# Altium schematic sheet sch.SchDoc(sch)
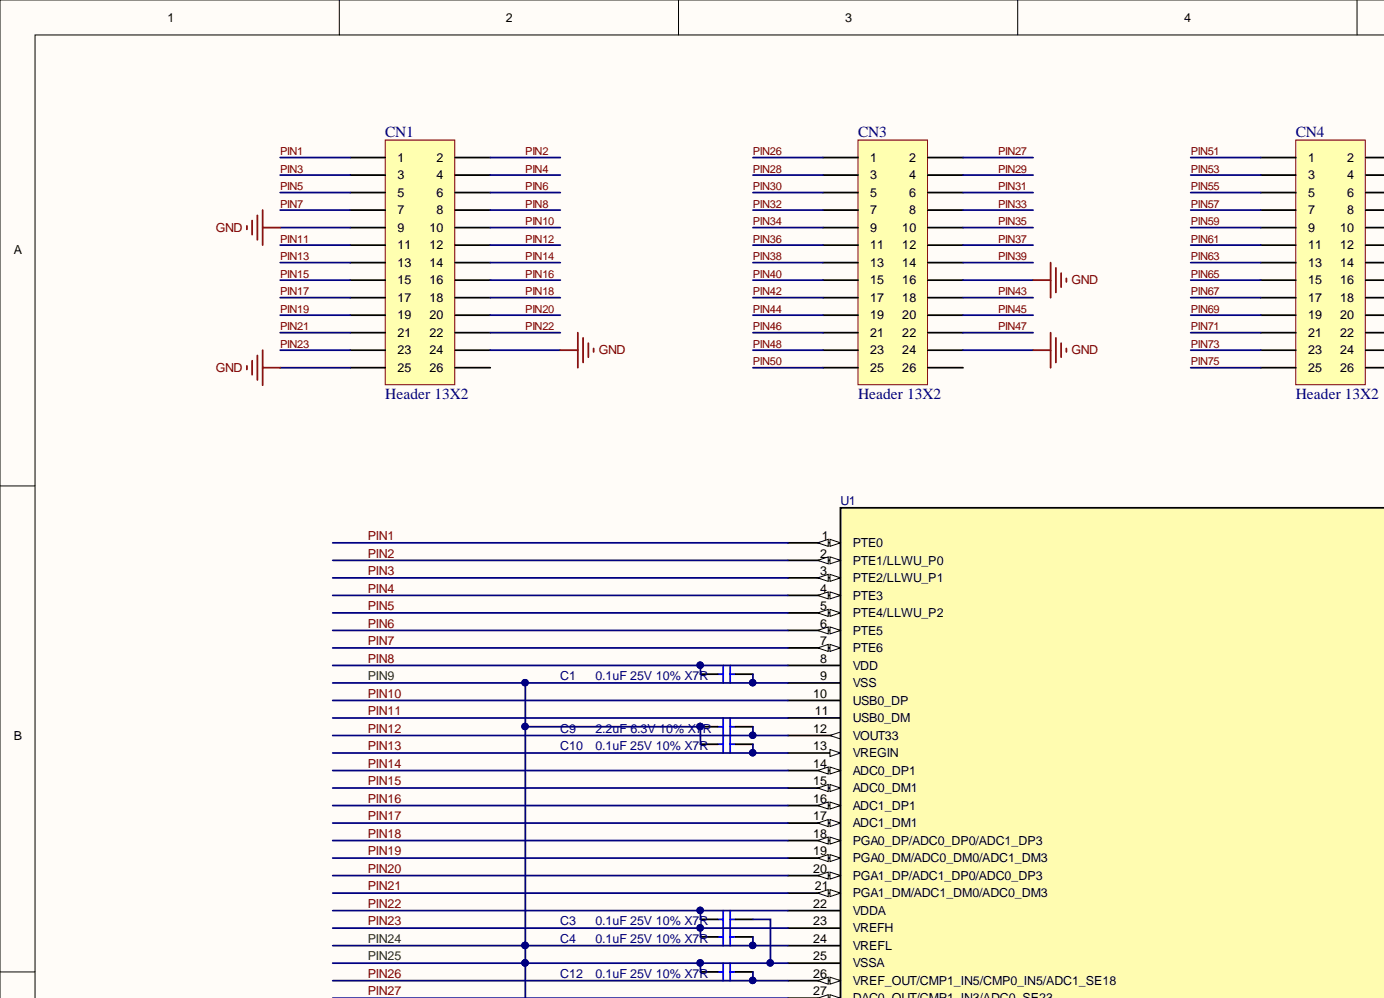
[diagram p0: sch.SchDoc, top left]
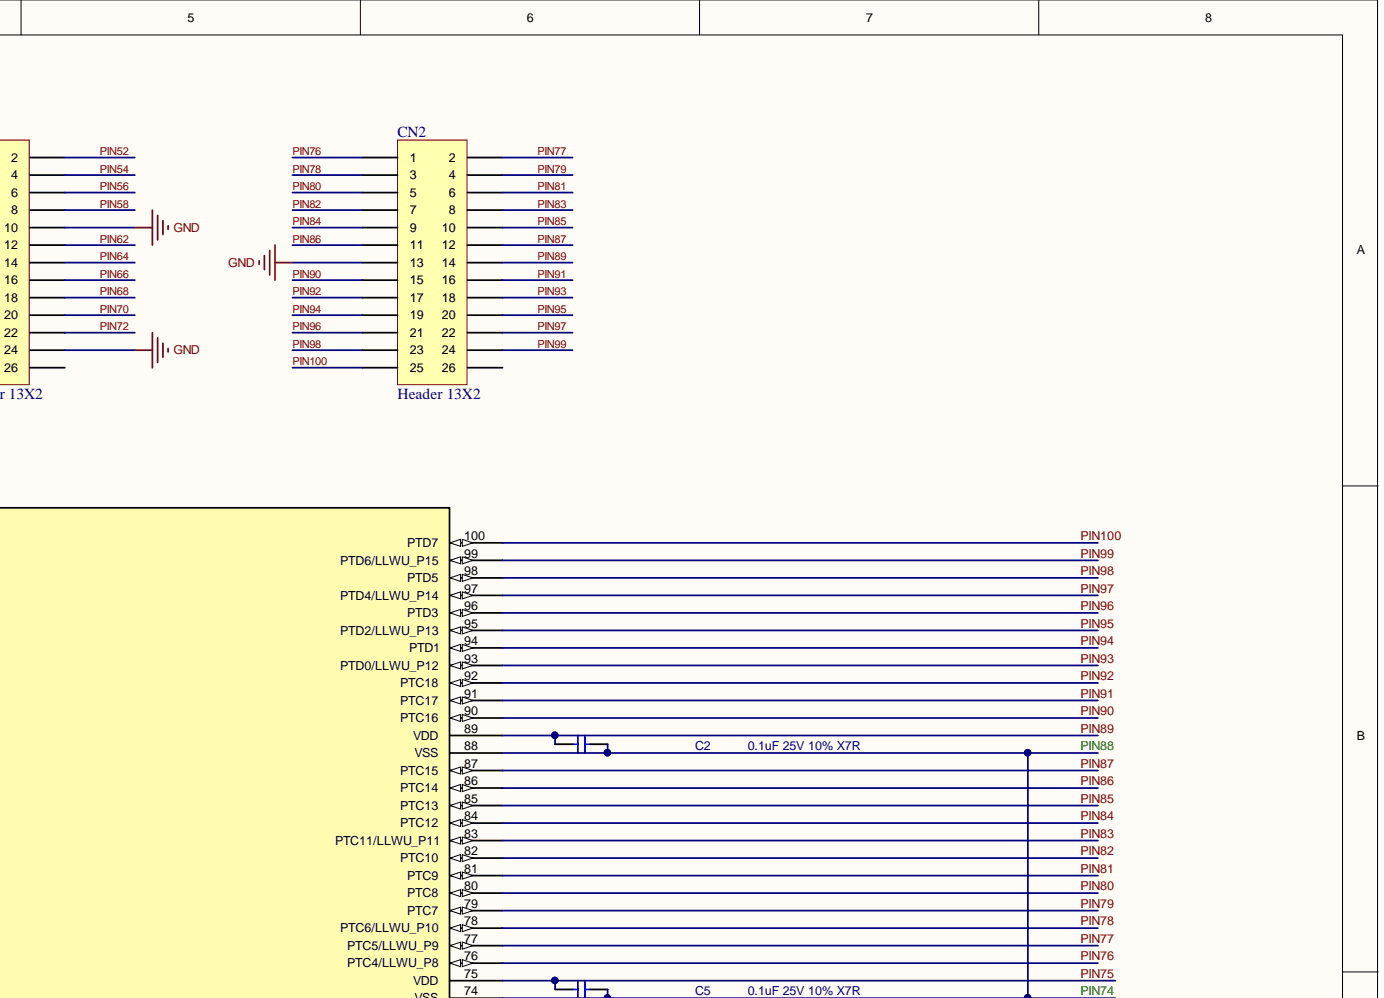
[diagram p1: sch.SchDoc, top right]
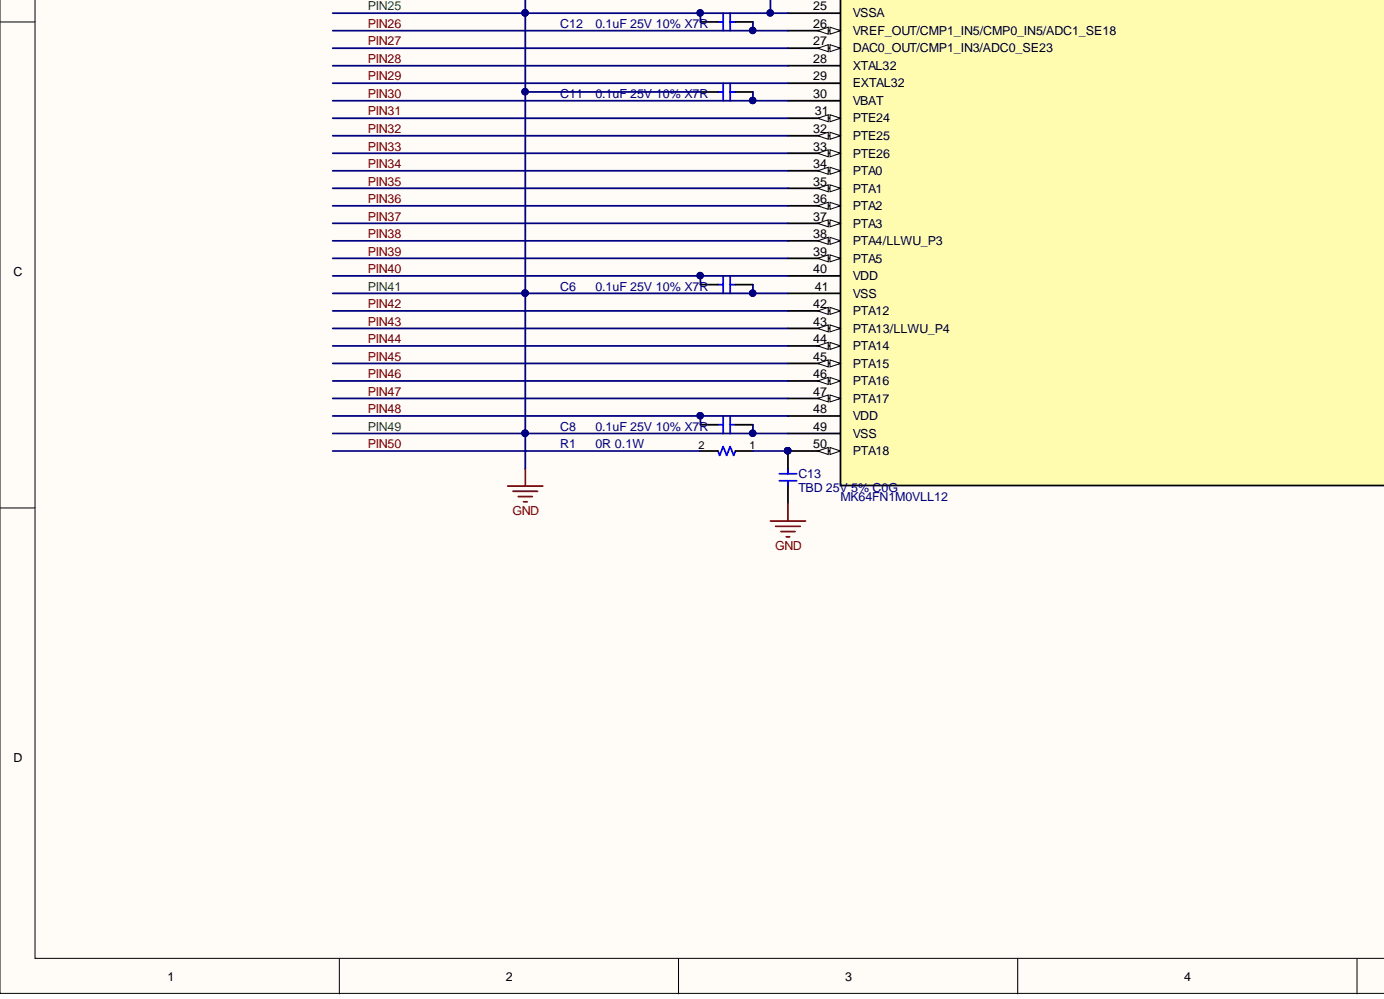
[diagram p2: sch.SchDoc, bottom left]
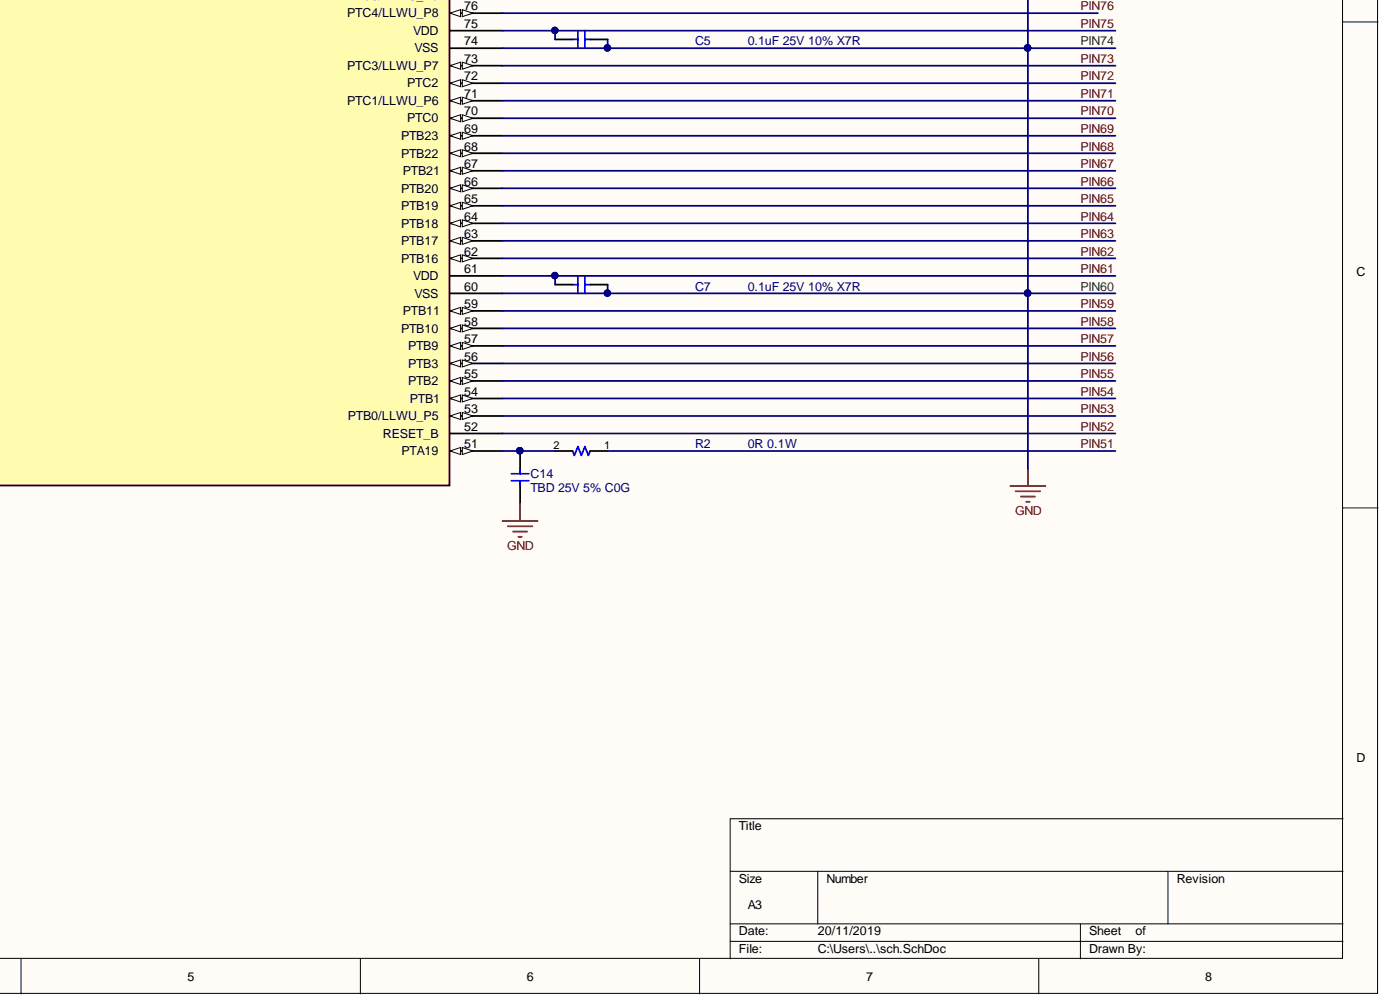
[diagram p3: sch.SchDoc, bottom right]

## Parts
C1  0.1uF 25V 10% X7R  value 0.1uF  MATERIAL: X7R  TOLERANCE: 10%  [CAPC1608X90N]
C2  0.1uF 25V 10% X7R  value 0.1uF  [CAPC1608X90N]
C3  0.1uF 25V 10% X7R  value 0.1uF  MATERIAL: X7R  TOLERANCE: 10%  [CAPC1608X90N]
C4  0.1uF 25V 10% X7R  value 0.1uF  MATERIAL: X7R  TOLERANCE: 10%  [CAPC1608X90N]
C5  0.1uF 25V 10% X7R  value 0.1uF  [CAPC1608X90N]
C6  0.1uF 25V 10% X7R  value 0.1uF  MATERIAL: X7R  TOLERANCE: 10%  [CAPC1608X90N]
C7  0.1uF 25V 10% X7R  value 0.1uF  [CAPC1608X90N]
C8  0.1uF 25V 10% X7R  value 0.1uF  MATERIAL: X7R  TOLERANCE: 10%  [CAPC1608X90N]
C9  2.2uF 6.3V 10% X7R  value 2.2uF  MATERIAL: X7R  TOLERANCE: 10%  [CAPC1608X90N]
C10  0.1uF 25V 10% X7R  value 0.1uF  MATERIAL: X7R  TOLERANCE: 10%  [CAPC1608X90N]
C11  0.1uF 25V 10% X7R  value 0.1uF  MATERIAL: X7R  TOLERANCE: 10%  [CAPC1608X90N]
C12  0.1uF 25V 10% X7R  value 0.1uF  MATERIAL: X7R  TOLERANCE: 10%  [CAPC1608X90N]
C13  TBD 25V 5% C0G  value TBD  [CAPC1608X90N]
C14  TBD 25V 5% C0G  value TBD  VOLTAGE: 25V  [CAPC1608X90N]
CN1  Header 13X2  [HDR2X13]
CN2  Header 13X2  [HDR2X13]
CN3  Header 13X2  [HDR2X13]
CN4  Header 13X2  [HDR2X13]
R1  0R 0.1W  value 0R  [RESC1608X40N]
R2  0R 0.1W  value 0R  [RESC1608X40N]
U1  MK64FN1M0VLL12  [QFP50P1600X1600X160-100N]

## Nets
GND: C1.1 C2.1 C3.1 C4.1 C5.1 C6.1 C7.1 C8.1 C9.2 C10.2 C11.2 C12.2 C13.2 C14.2 CN1.9 CN1.24 CN1.25 CN2.13 CN3.16 CN3.24 CN4.10 CN4.24 U1.9(VSS) U1.24(VREFL) U1.25(VSSA) U1.41(VSS) U1.49(VSS) U1.60(VSS) U1.74(VSS) U1.88(VSS)
PIN1: CN1.1 U1.1(PTE0)
PIN2: CN1.2 U1.2(PTE1/LLWU_P0)
PIN3: CN1.3 U1.3(PTE2/LLWU_P1)
PIN4: CN1.4 U1.4(PTE3)
PIN5: CN1.5 U1.5(PTE4/LLWU_P2)
PIN6: CN1.6 U1.6(PTE5)
PIN7: CN1.7 U1.7(PTE6)
PIN8: C1.2 CN1.8 U1.8(VDD)
PIN10: CN1.10 U1.10(USB0_DP)
PIN11: CN1.11 U1.11(USB0_DM)
PIN12: C9.1 CN1.12 U1.12(VOUT33)
PIN13: C10.1 CN1.13 U1.13(VREGIN)
PIN14: CN1.14 U1.14(ADC0_DP1)
PIN15: CN1.15 U1.15(ADC0_DM1)
PIN16: CN1.16 U1.16(ADC1_DP1)
PIN17: CN1.17 U1.17(ADC1_DM1)
PIN18: CN1.18 U1.18(PGA0_DP/ADC0_DP0/ADC1_DP3)
PIN19: CN1.19 U1.19(PGA0_DM/ADC0_DM0/ADC1_DM3)
PIN20: CN1.20 U1.20(PGA1_DP/ADC1_DP0/ADC0_DP3)
PIN21: CN1.21 U1.21(PGA1_DM/ADC1_DM0/ADC0_DM3)
PIN22: C3.2 CN1.22 U1.22(VDDA)
PIN23: C4.2 CN1.23 U1.23(VREFH)
PIN26: C12.1 CN3.1 U1.26(VREF_OUT/CMP1_IN5/CMP0_IN5/ADC1_SE18)
PIN27: CN3.2 U1.27(DAC0_OUT/CMP1_IN3/ADC0_SE23)
PIN28: CN3.3 U1.28(XTAL32)
PIN29: CN3.4 U1.29(EXTAL32)
PIN30: C11.1 CN3.5 U1.30(VBAT)
PIN31: CN3.6 U1.31(PTE24)
PIN32: CN3.7 U1.32(PTE25)
PIN33: CN3.8 U1.33(PTE26)
PIN34: CN3.9 U1.34(PTA0)
PIN35: CN3.10 U1.35(PTA1)
PIN36: CN3.11 U1.36(PTA2)
PIN37: CN3.12 U1.37(PTA3)
PIN38: CN3.13 U1.38(PTA4/LLWU_P3)
PIN39: CN3.14 U1.39(PTA5)
PIN40: C6.2 CN3.15 U1.40(VDD)
PIN42: CN3.17 U1.42(PTA12)
PIN43: CN3.18 U1.43(PTA13/LLWU_P4)
PIN44: CN3.19 U1.44(PTA14)
PIN45: CN3.20 U1.45(PTA15)
PIN46: CN3.21 U1.46(PTA16)
PIN47: CN3.22 U1.47(PTA17)
PIN48: C8.2 CN3.23 U1.48(VDD)
PIN50: CN3.25 R1.2
PIN51: CN4.1 R2.1
PIN52: CN4.2 U1.52(RESET_B)
PIN53: CN4.3 U1.53(PTB0/LLWU_P5)
PIN54: CN4.4 U1.54(PTB1)
PIN55: CN4.5 U1.55(PTB2)
PIN56: CN4.6 U1.56(PTB3)
PIN57: CN4.7 U1.57(PTB9)
PIN58: CN4.8 U1.58(PTB10)
PIN59: CN4.9 U1.59(PTB11)
PIN61: C7.2 CN4.11 U1.61(VDD)
PIN62: CN4.12 U1.62(PTB16)
PIN63: CN4.13 U1.63(PTB17)
PIN64: CN4.14 U1.64(PTB18)
PIN65: CN4.15 U1.65(PTB19)
PIN66: CN4.16 U1.66(PTB20)
PIN67: CN4.17 U1.67(PTB21)
PIN68: CN4.18 U1.68(PTB22)
PIN69: CN4.19 U1.69(PTB23)
PIN70: CN4.20 U1.70(PTC0)
PIN71: CN4.21 U1.71(PTC1/LLWU_P6)
PIN72: CN4.22 U1.72(PTC2)
PIN73: CN4.23 U1.73(PTC3/LLWU_P7)
PIN75: C5.2 CN4.25 U1.75(VDD)
PIN76: CN2.1 U1.76(PTC4/LLWU_P8)
PIN77: CN2.2 U1.77(PTC5/LLWU_P9)
PIN78: CN2.3 U1.78(PTC6/LLWU_P10)
PIN79: CN2.4 U1.79(PTC7)
PIN80: CN2.5 U1.80(PTC8)
PIN81: CN2.6 U1.81(PTC9)
PIN82: CN2.7 U1.82(PTC10)
PIN83: CN2.8 U1.83(PTC11/LLWU_P11)
PIN84: CN2.9 U1.84(PTC12)
PIN85: CN2.10 U1.85(PTC13)
PIN86: CN2.11 U1.86(PTC14)
PIN87: CN2.12 U1.87(PTC15)
PIN89: C2.2 CN2.14 U1.89(VDD)
PIN90: CN2.15 U1.90(PTC16)
PIN91: CN2.16 U1.91(PTC17)
PIN92: CN2.17 U1.92(PTC18)
PIN93: CN2.18 U1.93(PTD0/LLWU_P12)
PIN94: CN2.19 U1.94(PTD1)
PIN95: CN2.20 U1.95(PTD2/LLWU_P13)
PIN96: CN2.21 U1.96(PTD3)
PIN97: CN2.22 U1.97(PTD4/LLWU_P14)
PIN98: CN2.23 U1.98(PTD5)
PIN99: CN2.24 U1.99(PTD6/LLWU_P15)
PIN100: CN2.25 U1.100(PTD7)
NetC13_1: C13.1 R1.1 U1.50(PTA18)
NetC14_1: C14.1 R2.2 U1.51(PTA19)

Not connected: CN1 26; CN2 26; CN3 26; CN4 26

## Library data of the parts
C1-C14 = C: Capacitor, Ceramic; FP_DESC: C0603; MFG: <GENERIC>; Symbol Checked: Ok; Component Kind: Standard; MFG_PN: <GENERIC>; Footprint Checked: Assign OK, Footprint OK
  C1 C3 C4 C6 C8 C10-C12: VOLTAGE: 25V
  C2 C5 C7: MATERIAL: X7R; TOLERANCE: 10%; VOLTAGE: 25V
  C9: VOLTAGE: 6.3V
  C13: MATERIAL: C0G; TOLERANCE: 5%; VOLTAGE: 25V
  C14: MATERIAL: C0G; TOLERANCE: 5%
CN1-CN4 = Header 13X2: Header, 13-Pin, Dual row; Component Kind: Standard; LatestRevisionDate: 17-Jul-2002; LatestRevisionNote: Re-released for DXP Platform.; Library Name: Miscellaneous Connectors.IntLib; Signal Integrity: Connector; Publisher: Altium Limited
R1 R2 = Resistor: FP_DESC: R0603; MFG: <GENERIC>; Symbol Checked: Ok; Component Kind: Standard; Description: Resistor; MFG_PN: <GENERIC>; POWER: 0.1W; Footprint Checked: Assign OK, Footprint OK; Library Name: LAVIRG_V1_LIB.SchLib
U1 = MK64FN1M0VLL12: 120 MHz ARM Cortex®-M4-based Microcontroller with FPU; FP_DESC: LQFP100; MFG: NXP; Component Kind: Standard; MFG_PN: MK64FN1M0VLL12; Footprint Checked: Assign OK, Footprint OK
